# Altium schematic sheet 11 - POWER, RESET.SchDoc("11 - POWER, RESET")
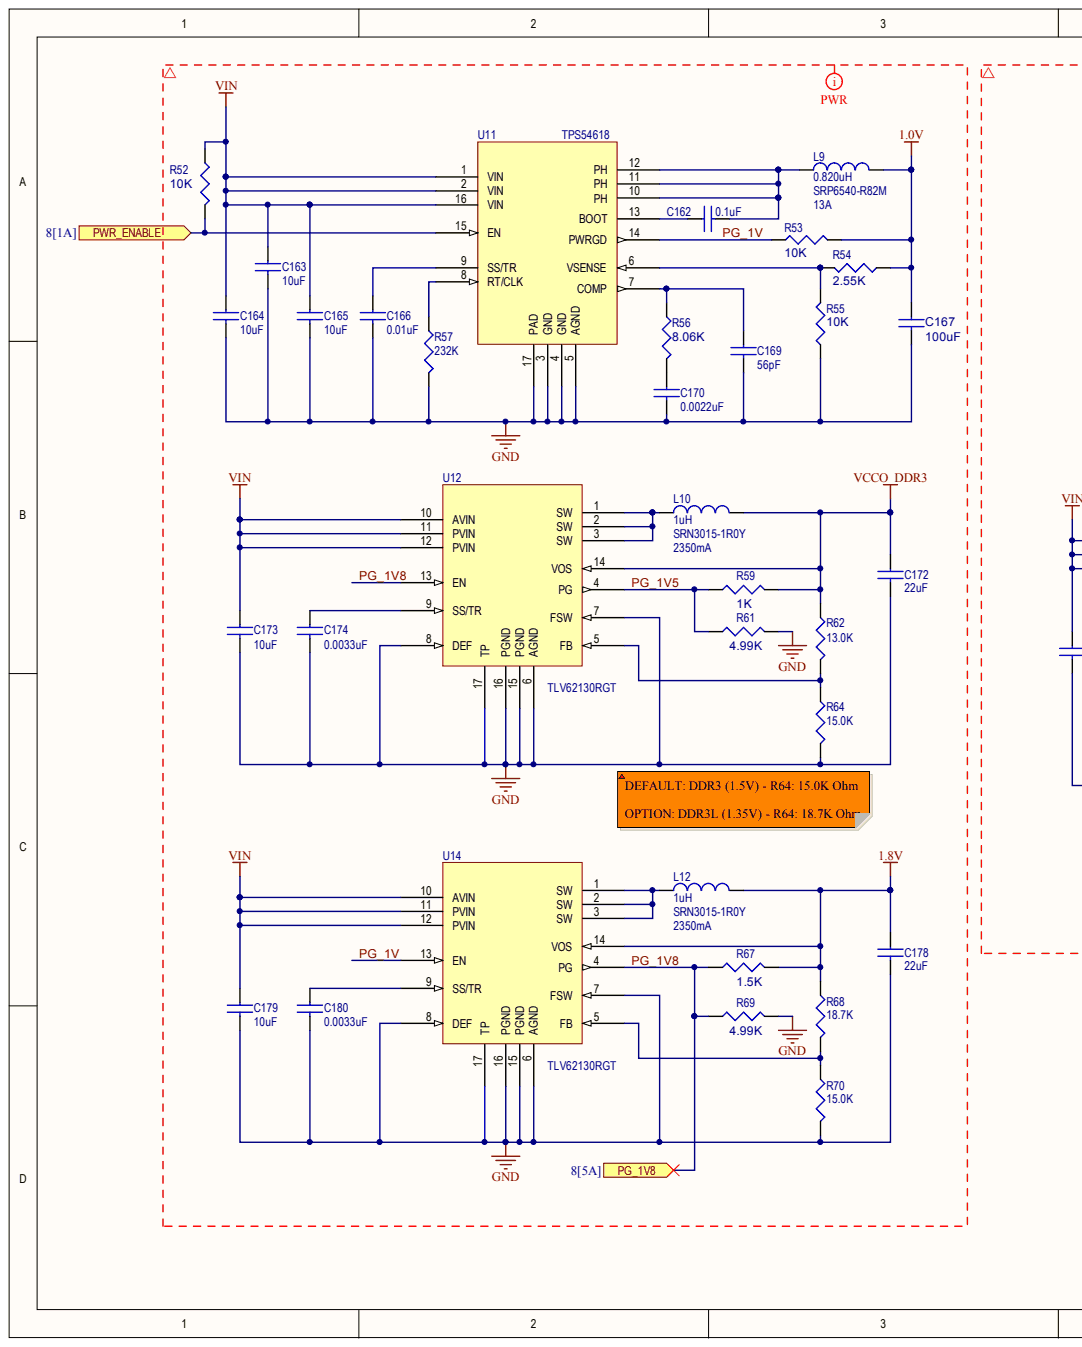
[diagram p0: 11 - POWER, RESET.SchDoc, left]
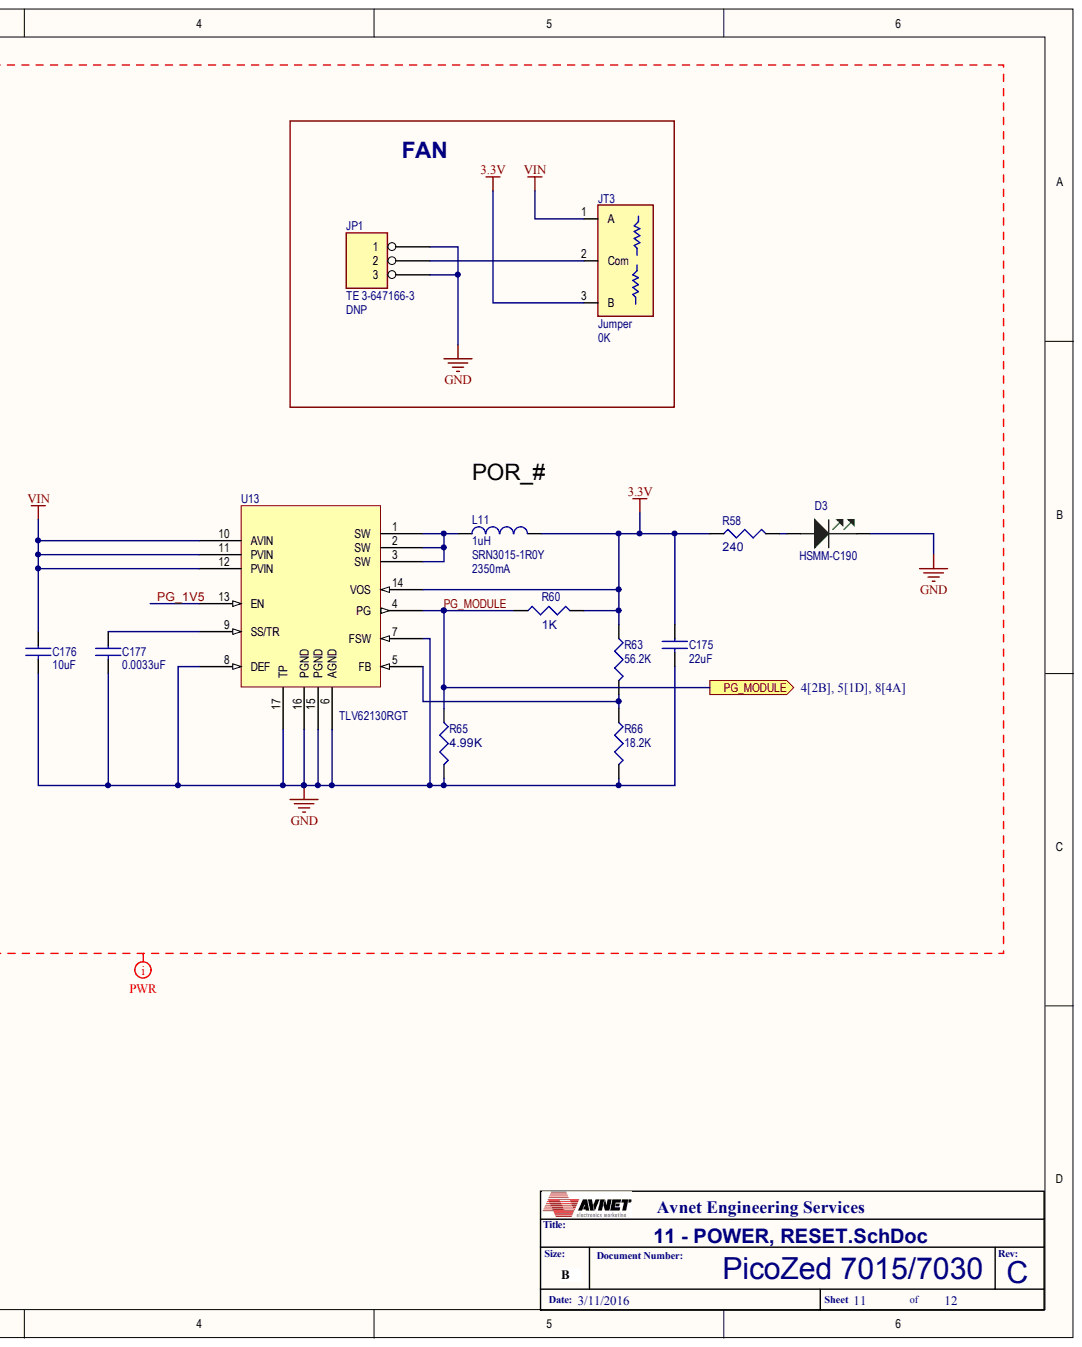
[diagram p1: 11 - POWER, RESET.SchDoc, right]

## Parts
C162  value 0.1uF  [C0201]  (hidden comment: PC522)
C163  value 10uF  [C1206]  (hidden comment: PC219)
C164  value 10uF  [C1206]  (hidden comment: PC219)
C165  value 10uF  [C1206]  (hidden comment: PC219)
C166  value 0.01uF  [C0201]  (hidden comment: PC003)
C167  100uF  [C1210]
C169  value 56pF  [C0402]  (hidden comment: PC013)
C170  value 0.0022uF  [C0402]  (hidden comment: PC025)
C172  value 22uF  [C0805]  (hidden comment: GRM219R61A226MEA0D)
C173  value 10uF  [C1206]  (hidden comment: PC219)
C174  value 0.0033uF  [C0402]  (hidden comment: PC190)
C175  value 22uF  [C0805]  (hidden comment: GRM219R61A226MEA0D)
C176  value 10uF  [C1206]  (hidden comment: PC219)
C177  value 0.0033uF  [C0402]  (hidden comment: PC190)
C178  value 22uF  [C0805]  (hidden comment: GRM219R61A226MEA0D)
C179  value 10uF  [C1206]  (hidden comment: PC219)
C180  value 0.0033uF  [C0402]  (hidden comment: PC190)
D3  Device: HSMM-C190  [LED_LITE-LTST-C191TBKT-G]  (hidden comment: DL018)
JP1  TE 3-647166-3  [3-647166-3]
JT3  value 0K  Device: Jumper  [R0402-DUAL_JMP]
L9  value 0.820uH  Device: 0.820uH  MFGPN: SRP6540-R82M  [IND_SRP6540]  (hidden comment: SPR6540-R82M)
L10  SRN3015-1R0Y  value 1uH  Device: 1uH  Imax: 2350mA  [IND_SRN3015]
L11  SRN3015-1R0Y  value 1uH  Device: 1uH  Imax: 2350mA  [IND_SRN3015]
L12  SRN3015-1R0Y  value 1uH  Device: 1uH  Imax: 2350mA  [IND_SRN3015]
R52  10K  [R0402]
R53  10K  [R0402]
R54  2.55K  [R0402]
R55  10K  [R0402]
R56  8.06K  [R0402]
R57  value 232K  [R0603]  (hidden comment: PR407)
R58  240  [R0402]
R59  1K  [R0402]
R60  1K  [R0402]
R61  4.99K  [R0402]
R62  value 13.0K  [R0402]  (hidden comment: PR043)
R63  value 56.2K  [R0402]  (hidden comment: PR101)
R64  value 15.0K  [R0402]  (hidden comment: PR046)
R65  4.99K  [R0402]
R66  value 18.2K  [R0402]  (hidden comment: PR051)
R67  1.5K  [R0402]
R68  value 18.7K  [R0402]  (hidden comment: PR536)
R69  4.99K  [R0402]
R70  value 15.0K  [R0402]  (hidden comment: PR046)
U11  TPS54618  [QFN16_3X3]
U12  TLV62130RGT  [QFN16_3X3]
U13  TLV62130RGT  [QFN16_3X3]
U14  TLV62130RGT  [QFN16_3X3]

## Ports
PG_1V8, PG_MODULE, PWR_ENABLE

## Nets
1.0V: C167.1 L9.2 R53.2 R54.1
1.8V: C178.1 L12.2 R67.1 R68.1 U14.14(VOS)
3.3V: C175.1 JT3.3 L11.2 R58.1 R60.1 R63.1 U13.14(VOS)
GND: C163.2 C164.2 C165.2 C166.2 C167.2 C169.2 C170.2 C172.2 C173.2 C174.2 C175.2 C176.2 C177.2 C178.2 C179.2 C180.2 D3.C JP1.1 JP1.3 R55.1 R57.2 R61.2 R64.2 R65.2 R66.2 R69.2 R70.2 U11.3(GND) U11.4(GND) U11.5(AGND) U11.17(PAD) U12.6(AGND) U12.7(FSW) U12.8(DEF) U12.15(PGND) U12.16(PGND) U12.17(TP) U13.6(AGND) U13.7(FSW) U13.8(DEF) U13.15(PGND) U13.16(PGND) U13.17(TP) U14.6(AGND) U14.7(FSW) U14.8(DEF) U14.15(PGND) U14.16(PGND) U14.17(TP)
VCCO_DDR3: C172.1 L10.2 R59.1 R62.1 U12.14(VOS)
VIN: C163.1 C164.1 C165.1 C173.1 C176.1 C179.1 JT3.1 R52.1 U11.1(VIN) U11.2(VIN) U11.16(VIN) U12.10(AVIN) U12.11(PVIN) U12.12(PVIN) U13.10(AVIN) U13.11(PVIN) U13.12(PVIN) U14.10(AVIN) U14.11(PVIN) U14.12(PVIN)
PG_1V: R53.1 U11.14(PWRGD) U14.13(EN)
PG_1V5: R59.2 R61.1 U12.4(PG) U13.13(EN)
PG_1V8: R67.2 R69.1 U12.13(EN) U14.4(PG) ; port PG_1V8
PG_MODULE: R60.2 R65.1 U13.4(PG) ; port PG_MODULE
PWR_ENABLE: R52.2 U11.15(EN) ; port PWR_ENABLE
NetC162_1: C162.1 U11.13(BOOT)
NetC162_2: C162.2 L9.1 U11.10(PH) U11.11(PH) U11.12(PH)
NetC166_1: C166.1 U11.9(SS/TR)
NetC169_1: C169.1 R56.1 U11.7(COMP)
NetC170_1: C170.1 R56.2
NetC174_1: C174.1 U12.9(SS/TR)
NetC177_1: C177.1 U13.9(SS/TR)
NetC180_1: C180.1 U14.9(SS/TR)
NetD3_A: D3.A R58.2
NetJP1_2: JP1.2 JT3.2
NetL10_1: L10.1 U12.1(SW) U12.2(SW) U12.3(SW)
NetL11_1: L11.1 U13.1(SW) U13.2(SW) U13.3(SW)
NetL12_1: L12.1 U14.1(SW) U14.2(SW) U14.3(SW)
NetR54_2: R54.2 R55.2 U11.6(VSENSE)
NetR57_1: R57.1 U11.8(RT/CLK)
NetR62_2: R62.2 R64.1 U12.5(FB)
NetR63_2: R63.2 R66.1 U13.5(FB)
NetR68_2: R68.2 R70.1 U14.5(FB)
N00732:
N00733:

## Library data of the parts
C162 = AVPC-0000522: CAP SM 0.1uF 16V 10% X5R C0201; PartCode: PC; Database Table Name: Passive-Cap$; MFG: TDK; MFGPN: C0603X5R1C104K030BC; Temp: I; AsyTxt: PC522; CLASSNAME: U11_BYP; Component Kind: Standard; ComponentLink1Description: Datasheet; Device: CAP; Dielectric: X5R; MFG Code: TDK; PkgType: SM; RoHS: Yes; Status: Preliminary; Tol: 10%; Volt: 16V; Library Name: Avnet_DBlib.DbLib
C163-C165 C173 C176 C179 = AVPC-0000219: CAP SM 10uF 25V 10% X5R C1206; PartCode: PC; Database Table Name: Passive-Cap$; MFG: AVX; MFGPN: 12063D106KAT2A; Temp: I; AsyTxt: PC219; AVLNo: AVPC-0000219; Component Kind: Standard; ComponentLink1Description: Datasheet; ComponentLink2Description: MFR Website; ComponentLink2URL: www.avx.com; Device: CAP; Dielectric: X5R; ESR: CAP; MFG Code: AVX; PkgType: SM; RoHS: Yes; Status: Preliminary; Tol: 10%; Volt: 25V; ComponentLink1URL: C:\AVDBLIB\DATASHEETS\AVX_CX5R.pdf; Library Name: Avnet_DBlib.DbLib
C166 = AVPC-0000003: CAP SM 0.01uF 10V 10% X5R C0201; PartCode: PC; Database Table Name: Passive-Cap$; MFG: AVX; MFGPN: 0201ZD103KAT2A; Temp: I; AsyTxt: PC003; AVLNo: AVPC-0000003; CLASSNAME: U3-BYP; Component Kind: Standard; ComponentLink1Description: Datasheet; ComponentLink2Description: MFR Website; ComponentLink2URL: www.avx.com; Device: CAP; Dielectric: X5R; ESR: CAP; PkgType: SM; RoHS: Yes; Status: Preliminary; Tol: 10%; Volt: 10V; ComponentLink1URL: C:\AVDBLIB\DATASHEETS\AVX_CX5R.pdf; Library Name: Avnet_DBlib.DbLib
C167 = AVPC-0000209: CAP SM 100uF 6.3V 20% X5R C1206; PartCode: PC; AsyTxt: PC209; Database Table Name: Passive-Cap$; MFG: Murata; MFG Code: MUR; MFGPN: GRM32EF50J107ZE20K; Power: 0; Temp: I; Availability: 180K-AV; AVLNo: AVPC-0000209; CLASSNAME: DDR_TERM; Component Kind: Standard; ComponentLink1Description: Datasheet; Cost: .41; Device: CAP; Dielectric: X5R; PkgType: SM; Received: 0; RoHS: Yes; Size: 9.11; Status: Preliminary; Tol: 20%; Value: 100uF; Volt: 6.3V; ComponentLink1URL: C:\AVDBLIB\DATASHEETS\GRM31CR60J107ME39.pdf; Library Name: Avnet_DBlib.DbLib
C169 = AVPC-0000013: CAP SM 56pF 25V 10% NPO C0402; PartCode: PC; Database Table Name: Passive-Cap$; MFG: AVX; MFGPN: 04023A560KAT2A; Temp: I; AsyTxt: PC013; AVLNo: AVPC-0000013; Component Kind: Standard; ComponentLink1Description: Datasheet; ComponentLink2Description: MFR Website; ComponentLink2URL: www.avx.com; Device: CAP; Dielectric: NPO; ESR: CAP; PkgType: SM; RoHS: Yes; Status: Preliminary; Tol: 10%; Volt: 25V; ComponentLink1URL: C:\AVDBLIB\DATASHEETS\AVX_ccog.pdf; Library Name: Avnet_DBlib.DbLib
C170 = AVPC-0000025: CAP SM 0.0022uF 16V 10% X7R C0402; PartCode: PC; Database Table Name: Passive-Cap$; MFG: AVX; MFGPN: 0402YC222KAT2A; Temp: I; AsyTxt: PC025; AVLNo: AVPC-0000025; Component Kind: Standard; ComponentLink1Description: Datasheet; ComponentLink2Description: MFR Website; ComponentLink2URL: www.avx.com; Device: CAP; Dielectric: X7R; ESR: CAP; PkgType: SM; RoHS: Yes; Status: Preliminary; Tol: 10%; Volt: 16V; ComponentLink1URL: C:\AVDBLIB\DATASHEETS\AVX_CX7R.pdf; Library Name: Avnet_DBlib.DbLib
C172 C175 C178 = (no symbol name): CAP SM 22uF 10V 20% X5R C0805; PartCode: PC; AsyTxt: PC112; AVLNo: AVPC-0000531; ESR: CAP-P; MFG: Taiyo Yuden; MFG Code: TY; MFGPN: EMK212BBJ226MG-T; Temp: I; Tol: 10%; Component Kind: Standard; ComponentLink1Description: Datasheet; Device: CAP; Dielectric: X5R; Library Name: Zynq_Z010_ZedStick.SchLib; PkgType: SM; RoHS: Yes; Status: Preliminary; Volt: 10V
C174 C177 C180 = AVPC-0000190: CAP SM 0.0033uF 25V 5% X7R C0402; PartCode: PC; Database Table Name: Passive-Cap$; MFG: AVX; MFGPN: 04023C332JAT2A; Temp: I; AsyTxt: PC190; AVLNo: AVPC-0000190; Component Kind: Standard; ComponentLink1Description: Datasheet; ComponentLink2Description: MFR Website; ComponentLink2URL: www.avx.com; Device: CAP; Dielectric: X7R; ESR: CAP; MFG Code: AVX; PkgType: SM; RoHS: Yes; Status: Preliminary; Tol: 5%; Volt: 25V; ComponentLink1URL: C:\AVDBLIB\DATASHEETS\AVX_CX7R.pdf; Library Name: Avnet_DBlib.DbLib
D3 = AVDL-0000018: LED, GREEN; PartCode: DL; Color: GRN; Database Table Name: Discrete-LED$; If: 20mA; Intensity at If: 150mcd; MFG: Avago; Temp: I; Text: LED5; Vf: 3.2V; WaveLength: 525nm; AsyTxt: DL018; Component Kind: Standard; ComponentLink1Description: Datasheet; Device Type: LED, GREEN; MFGPN: HSMM-C190; Status: Preliminary; Library Name: Avnet_DBlib.DbLib
JP1 = TE 3-647166-3: CONN HEADER 3POS SMT; MFG: TE Connectivity; Temp: C; Component Kind: Standard; DNP: DNP; Library Name: new.SchLib; MFGPN: 3-647166-3; Status: Preliminary
JT3 = AVPO-0000025: Jumper 3-pad 0402 Pin 1-2; PartCode: PO; Database Table Name: Passive-Other$; Device Type: 3-pad 0R0 0402; MFG: Vishay; MFGPN: CRCW04020000Z0ED; Note: Position 1-2; PkgType: SM; Temp: I; Component Kind: Standard; ComponentLink1Description: Datasheet; Part #: CRCW04020000Z0ED; RoHS: Yes; Status: Preliminary; ComponentLink1URL: C:\AVDBLIB\DATASHEETS\Dale_CRCW.pd; Library Name: Avnet_DBlib.DbLib
L9 = (no symbol name): IND SM 0.800uH 15A DCR=5.14mOhm; PartCode: PI; Imax: 13A; MFG: Bourns; Temp: C; Component Kind: Standard; Library Name: Zynq_Z010_ZedStick.SchLib; PkgType: SM; Status: Preliminary
L10-L12 = (no symbol name): IND SM 1uH 2.35A DCR=48mOhm; PartCode: PI; AVLNo: AVPI-0000091; MFG: Bourns; MFG Code: BNS; Temp: C; Component Kind: Standard; ComponentLink1Description: Datasheet; Library Name: Zynq_Z010_ZedStick.SchLib; MFGPN: SRN3015-1R0Y; PkgType: SM; Status: Preliminary
R52 R53 R55 = AVPR-0000034: RES SM 10K 62mW 0.01 R0402; PartCode: PR; AsyTxt: PR034; Database Table Name: Passive-Res$; MFG: Vishay; MFG Code: DAL; MFGPN: CRCW040210K0FKED; Power: 0; Temp: I; Tol: 0.01; Watt: 63mW; Availability: 2M-AV; AVLNo: AVPR-0000034; CLASSNAME: DDR_TERM; Component Kind: Standard; ComponentLink1Description: Datasheet; Cost: .01; Device: RES; PkgType: SM; Received: 0; RoHS: Yes; Size: 1.9; Status: Preliminary; Value: 10K; Watt: 62mW; ComponentLink1URL: C:\AVDBLIB\DATASHEETS\Dale_CRCW.pd; Library Name: Avnet_DBlib.DbLib
R54 = AVPR-0000034: RES SM 2.55K 62mW 0.01 R0402; PartCode: PR; Database Table Name: Passive-Res$; MFG: Vishay; MFGPN: CRCW04022K55FKED; Power: 0; Temp: I; Tol: 0.01; Watt: 63mW; Component Kind: Standard; Cost: .01; Device: RES; PkgType: SM; Received: 0; RoHS: Yes; Size: 1.9; Status: Preliminary; Value: 2.55K; Watt: 62mW; Library Name: Avnet_DBlib.DbLib
R56 = AVPR-0000034: RES SM 8.06K 62mW 0.01 R0402; PartCode: PR; Database Table Name: Passive-Res$; MFG: Vishay; MFG Code: DAL; MFGPN: CRCW04028K06FKED; Power: 0; Temp: I; Tol: 0.01; Watt: 63mW; Component Kind: Standard; Cost: .01; Device: RES; PkgType: SM; Received: 0; RoHS: Yes; Status: Preliminary; Value: 8.06K; Watt: 62mW; Library Name: Avnet_DBlib.DbLib
R57 = AVPR-0000407: RES SM 232K  0.1W 0.01 R0603; PartCode: PR; Database Table Name: Passive-Res$; MFG: Vishay; MFGPN: CRCW0603232KFKEA; Temp: I; Tol: 0.01; AsyTxt: PR407; AVLNo: AVPR-0000407; Component Kind: Standard; ComponentLink1Description: Datasheet; Device: RES; PkgType: SM; RoHS: Yes; Status: Preliminary; Watt: 0.1W; ComponentLink1URL: C:\AVDBLIB\DATASHEETS\Dale_CRCW.pd; Library Name: Avnet_DBlib.DbLib
R58 = AVPR-0000511: RES SM 240 62mW 0.01 R0402; Alt1MFR: NIC; PartCode: PR; Alt1PN: NRC04F2400TRF; AsyTxt: PR511; Database Table Name: Passive-Res$; MFG: Vishay; MFG Code: DAL; MFGPN: CRCW0402240RFKED; Power: 0; Temp: I; Tol: 0.01; Availability: 1M-AV; AVLNo: AVPR-0000511; Component Kind: Standard; ComponentLink1Description: Datasheet; Cost: .01; Device: RES; PkgType: SM; Received: 0; RoHS: Yes; Size: 1.9; Status: Preliminary; Value: 240; Watt: 62mW; ComponentLink1URL: C:\AVDBLIB\DATASHEETS\Dale_CRCW.pd; Library Name: Avnet_DBlib.DbLib
R59 R60 = AVPR-0000052: RES SM 1K 62mW 0.01 R0402; PartCode: PR; AsyTxt: PR052; Database Table Name: Passive-Res$; MFG: Vishay; MFG Code: DAL; MFGPN: CRCW04021K00FKED; Temp: I; Tol: 0.01; AVLNo: AVPR-0000052; Component Kind: Standard; ComponentLink1Description: Datasheet; Device: RES; PkgType: SM; RoHS: Yes; Status: Preliminary; Value: 1K; Watt: 62mW; ComponentLink1URL: C:\AVDBLIB\DATASHEETS\Dale_CRCW.pd; Library Name: Avnet_DBlib.DbLib
R61 R65 R69 = AVPR-0000094: RES SM 4.99K 62mW 0.01 R0402; PartCode: PR; AsyTxt: PR094; Database Table Name: Passive-Res$; MFG: Vishay; MFG Code: DAL; MFGPN: CRCW04024K99FKED; Temp: I; Tol: 0.01; AVLNo: AVPR-0000094; Component Kind: Standard; ComponentLink1Description: Datasheet; Device: RES; PkgType: SM; RoHS: Yes; Status: Preliminary; Value: 4.99K; Watt: 62mW; ComponentLink1URL: C:\AVDBLIB\DATASHEETS\Dale_CRCW.pd; Library Name: Avnet_DBlib.DbLib
R62 = AVPR-0000043: RES SM 13.0K 62mW 0.01 R0402; PartCode: PR; Database Table Name: Passive-Res$; MFG: Vishay; MFGPN: CRCW040213K0FKED; Temp: I; Tol: 0.01; AsyTxt: PR043; AVLNo: AVPR-0000043; Component Kind: Standard; ComponentLink1Description: Datasheet; Device: RES; PkgType: SM; RoHS: Yes; Status: Preliminary; Watt: 62mW; ComponentLink1URL: C:\AVDBLIB\DATASHEETS\Dale_CRCW.pd; Library Name: Avnet_DBlib.DbLib
R63 = AVPR-0000101: RES SM 56.2K 62mW 0.01 R0402; PartCode: PR; Database Table Name: Passive-Res$; MFG: Vishay; MFGPN: CRCW040256K2FKED; Temp: I; Tol: 0.01; AsyTxt: PR101; AVLNo: AVPR-0000101; Component Kind: Standard; ComponentLink1Description: Datasheet; Device: RES; PkgType: SM; RoHS: Yes; Status: Preliminary; Watt: 62mW; ComponentLink1URL: C:\AVDBLIB\DATASHEETS\Dale_CRCW.pd; Library Name: Avnet_DBlib.DbLib
R64 R70 = AVPR-0000046: RES SM 15.0K 62mW 0.01 R0402; PartCode: PR; Database Table Name: Passive-Res$; MFG: Vishay; MFG Code: DAL; MFGPN: CRCW040215K0FKED; Temp: I; Tol: 0.01; AsyTxt: PR046; AVLNo: AVPR-0000046; Component Kind: Standard; ComponentLink1Description: Datasheet; Device: RES; PkgType: SM; RoHS: Yes; Status: Preliminary; Watt: 62mW; ComponentLink1URL: C:\AVDBLIB\DATASHEETS\Dale_CRCW.pd; Library Name: Avnet_DBlib.DbLib
R66 = AVPR-0000051: RES SM 18.2K 62mW 0.01 R0402; PartCode: PR; Database Table Name: Passive-Res$; MFG: Vishay; MFGPN: CRCW040218K2FKED; Temp: I; Tol: 0.01; AsyTxt: PR051; AVLNo: AVPR-0000051; Component Kind: Standard; ComponentLink1Description: Datasheet; Device: RES; PkgType: SM; RoHS: Yes; Status: Preliminary; Watt: 62mW; ComponentLink1URL: C:\AVDBLIB\DATASHEETS\Dale_CRCW.pd; Library Name: Avnet_DBlib.DbLib
R67 = AVPR-0000052: RES SM 1.5K 62mW 0.01 R0402; PartCode: PR; Database Table Name: Passive-Res$; MFG: Vishay; MFGPN: CRCW04021K50FKED; Temp: I; Tol: 0.01; Component Kind: Standard; Device: RES; PkgType: SM; RoHS: Yes; Status: Preliminary; Value: 1.5K; Watt: 62mW; Library Name: Avnet_DBlib.DbLib
R68 = AVPR-0000536: RES SM 18.7K  62mW 0.01 R0402; PartCode: PR; Database Table Name: Passive-Res$; MFG: Vishay; MFGPN: CRCW040218K7FKED; Temp: I; Tol: 0.01; AsyTxt: PR536; AVLNo: AVPR-0000536; Component Kind: Standard; ComponentLink1Description: Datasheet; Device: RES; PkgType: SM; RoHS: Yes; Status: Preliminary; Watt: 62mW; ComponentLink1URL: C:\AVDBLIB\DATASHEETS\Dale_CRCW.pd; Library Name: Avnet_DBlib.DbLib
U11 = TPS54618: Step Dowm Switcher, 6A, 3V-17V, Adjustable; MFG: Texas Instruments; MFGPN: TPS54618RTE; Temp: C; Component Kind: Standard; Library Name: PicoZed+_Rev_A.SCHLIB
U12-U14 = TLV62130RGT: Step Dowm Switcher, 3A, 3V-17V, Adjustable; MFG: Texas Instruments; MFG CODE: TIS; Temp: C; Component Kind: Standard; Library Name: Zynq_Z010_ZedStick.SchLib; MFGPN: TLV62130RGT
